# Altium schematic sheet EEG_Electrodes.SchDoc
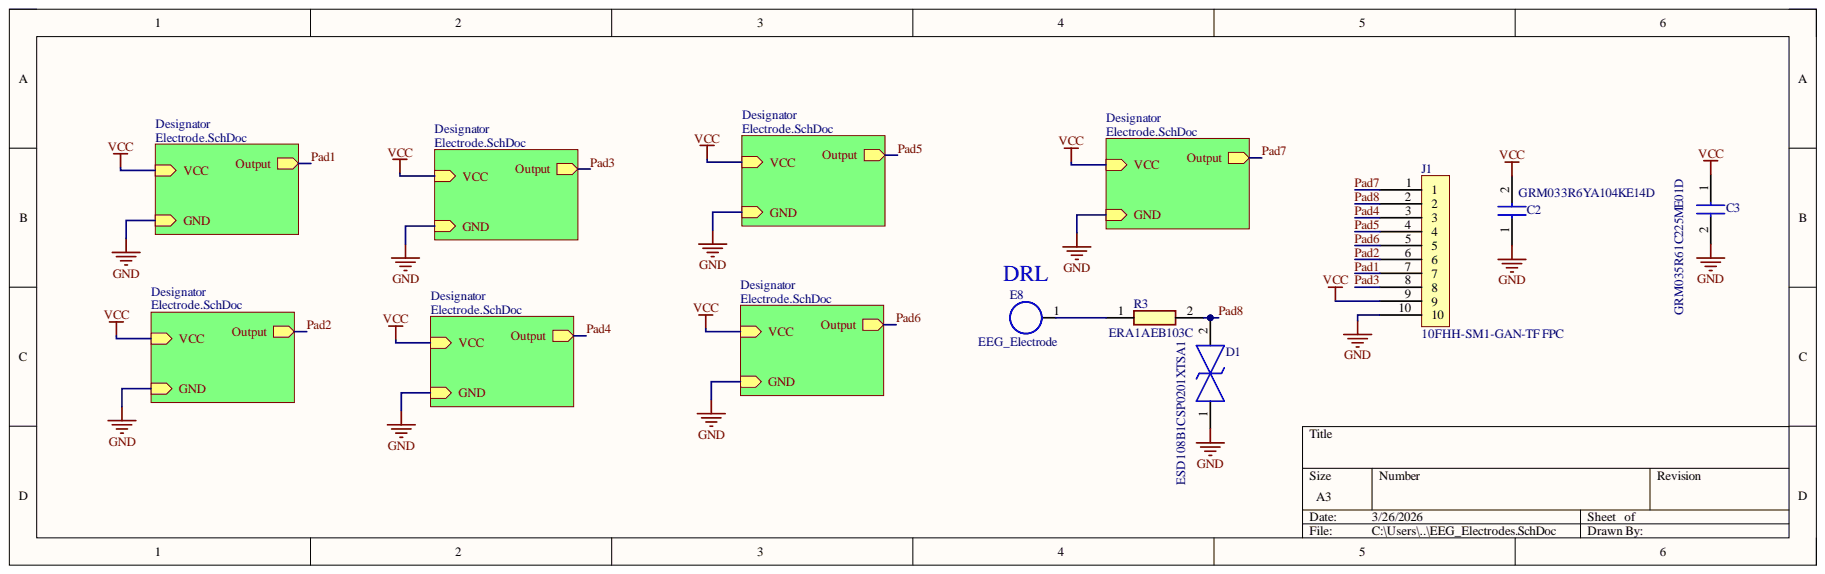
[diagram p0: EEG_Electrodes.SchDoc]

## Parts
C2  GRM033R6YA104KE14D  [CAPC0603X33N]
C3  GRM035R61C225ME01D  [GRM033D70J224ME01D]
D1  ESD108B1CSP0201XTSA1  [ESD108B1CSP0201XTSA1]
E8  EEG_Electrode  [EEG_Electrode]
J1  10FHH-SM1-GAN-TF FPC  [10FHH-SM1-GAN-TF FPC]
R3  ERA1AEB103C  [ERA1A_(0201)]

## Nets
GND: C2.1 C3.2 D1.1 J1.10
VCC: C2.2 C3.1 J1.9
Pad1: J1.7
Pad2: J1.6
Pad3: J1.8
Pad4: J1.3
Pad5: J1.4
Pad6: J1.5
Pad7: J1.1
Pad8: D1.2 J1.2 R3.2
NetE8_1: E8.1 R3.1

## Library data of the parts
C2 = GRM033R6YA104KE14D: Capacitor 0.1uF 0201; Manufacturer_Name: Murata Electronics; Arrow Part Number: GRM033R6YA104KE14D; Arrow Price/Stock: https://www.arrow.com/en/products/grm033r6ya104ke14d/murata-manufacturing?utm_currency=USD&region=europe; Component Kind: Standard; Datasheet Link: https://search.murata.co.jp/Ceramy/image/img/A01X/G101/ENG/GRM033R6YA104KE14-01A.pdf; Height: 0.33mm; Manufacturer_Part_Number: GRM033R6YA104KE14D; Mouser Part Number: 81-GRM33R6YA104KE14D; Mouser Price/Stock: https://www.mouser.co.uk/ProductDetail/Murata-Electronics/GRM033R6YA104KE14D?qs=P%2FC4Eoq9CDwTt3wOuyKhXw%3D%3D
C3 = GRM035R61C225ME01D: Capacitor 2.2uF 0201; Manufacturer_Name: Murata Electronics; Component Kind: Standard; Datasheet Link: https://search.murata.co.jp/Ceramy/image/img/A01X/G101/ENG/GRM035R61C225ME01-01A.pdf; Height: 0.33mm; Library Name: SamacSys.SchLib; Manufacturer_Part_Number: GRM035R61C225ME01D; Mouser Part Number: 81-GRM035R61C225ME1D; Mouser Price/Stock: https://www.mouser.co.uk/ProductDetail/Murata-Electronics/GRM035R61C225ME01D?qs=DPoM0jnrROXTliYh3Jmu1A%3D%3D
D1 = ESD108B1CSP0201XTSA1: TVS Diode (Bi-directional); Manufacturer_Name: Infineon; Arrow Part Number: ESD108B1CSP0201XTSA1; Arrow Price/Stock: https://www.arrow.com/en/products/esd108b1csp0201xtsa1/infineon-technologies-ag?region=nac; Component Kind: Standard; Datasheet Link: https://www.infineon.com/dgdl/Infineon-ESD108-B1-CSP0201-DS-v01_00-EN.pdf?fileId=5546d4624b0b249c014b1746e4d05aa8; Height: 0.16mm; Library Name: SamacSys.SchLib; Manufacturer_Part_Number: ESD108B1CSP0201XTSA1; Mouser Part Number: 726-ESD108B1CSP0201X; Mouser Price/Stock: https://www.mouser.co.uk/ProductDetail/Infineon-Technologies/ESD108B1CSP0201XTSA1?qs=3Bi3m9r5MQZFyNTZtNMRAQ%3D%3D
R3 = ERA1AEB103C: Resistor 10k; Manufacturer_Name: Panasonic; Component Kind: Standard; Datasheet Link: http://industrial.panasonic.com/cdbs/www-data/pdf/RDM0000/AOA0000C307.pdf; Height: 0.26mm; Library Name: SamacSys.SchLib; Manufacturer_Part_Number: ERA1AEB103C
E8 = EEG_Electrode: Component Kind: Standard; Description: EEG_Electrode; Library Name: Rishabh_Schematic_Library.SchLib
J1 = 10FHH-SM1-GAN-TF FPC: Component Kind: Standard; Library Name: Rishabh_Schematic_Library.SchLib
